# Altium schematic sheet IoTextra Analog_Diffirential Amplifier.SchDoc("IoTextra Analog_Diffirential Amplifier")
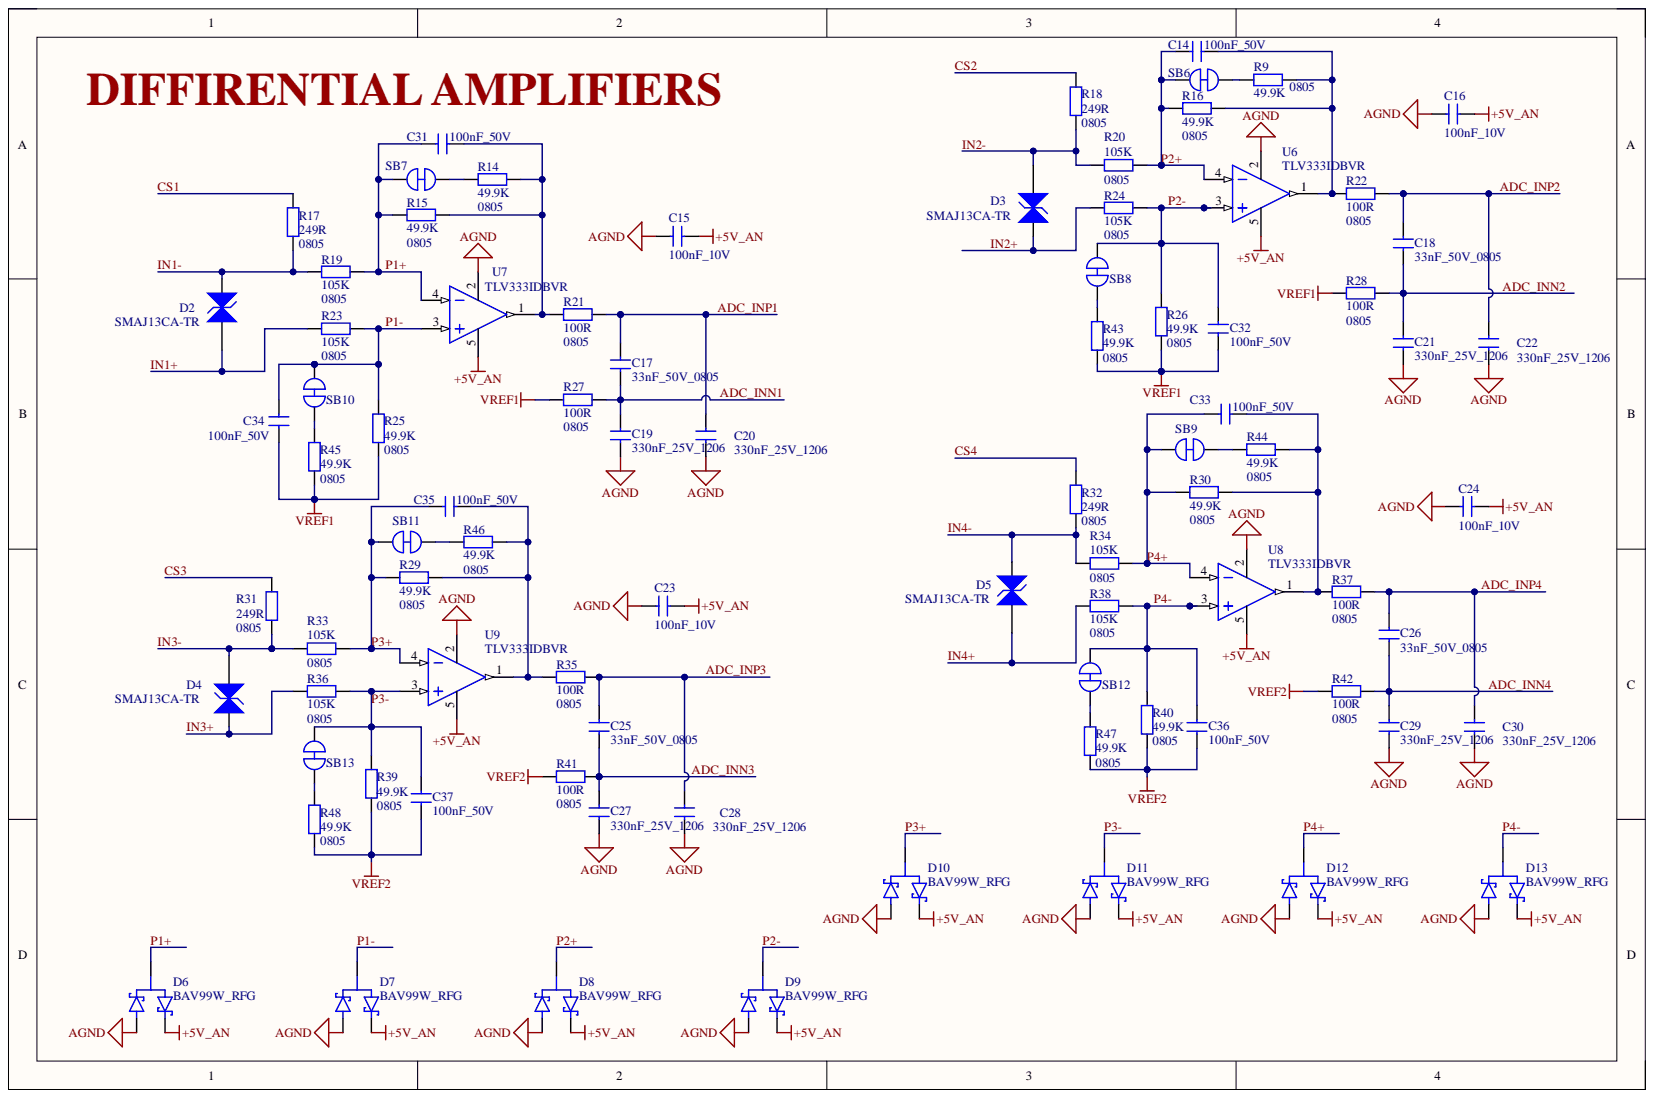
[diagram p0: IoTextra Analog_Diffirential Amplifier.SchDoc]

## Parts
C14  100nF_50V  [C1206]
C15  100nF_10V  [CN-SMD-0402]
C16  100nF_10V  [CN-SMD-0402]
C17  33nF_50V_0805  [C0805]
C18  33nF_50V_0805  [C0805]
C19  330nF_25V_1206  [C1206]
C20  330nF_25V_1206  [C1206]
C21  330nF_25V_1206  [C1206]
C22  330nF_25V_1206  [C1206]
C23  100nF_10V  [CN-SMD-0402]
C24  100nF_10V  [CN-SMD-0402]
C25  33nF_50V_0805  [C0805]
C26  33nF_50V_0805  [C0805]
C27  330nF_25V_1206  [C1206]
C28  330nF_25V_1206  [C1206]
C29  330nF_25V_1206  [C1206]
C30  330nF_25V_1206  [C1206]
C31  100nF_50V  [C1206]
C32  100nF_50V  [C1206]
C33  100nF_50V  [C1206]
C34  100nF_50V  [C1206]
C35  100nF_50V  [C1206]
C36  100nF_50V  [C1206]
C37  100nF_50V  [C1206]
D2  SMAJ13CA-TR  [DIODE_DO214AC_SMA]
D3  SMAJ13CA-TR  [DIODE_DO214AC_SMA]
D4  SMAJ13CA-TR  [DIODE_DO214AC_SMA]
D5  SMAJ13CA-TR  [DIODE_DO214AC_SMA]
D6  BAV99W_RFG  [DIO_BAV99W RF]
D7  BAV99W_RFG  [DIO_BAV99W RF]
D8  BAV99W_RFG  [DIO_BAV99W RF]
D9  BAV99W_RFG  [DIO_BAV99W RF]
D10  BAV99W_RFG  [DIO_BAV99W RF]
D11  BAV99W_RFG  [DIO_BAV99W RF]
D12  BAV99W_RFG  [DIO_BAV99W RF]
D13  BAV99W_RFG  [DIO_BAV99W RF]
R9  49.9K  [0805]
R14  49.9K  [0805]
R15  49.9K  [0805]
R16  49.9K  [0805]
R17  249R  [0805]
R18  249R  [0805]
R19  105K  [0805]
R20  105K  [0805]
R21  100R  [0805]
R22  100R  [0805]
R23  105K  [0805]
R24  105K  [0805]
R25  49.9K  [0805]
R26  49.9K  [0805]
R27  100R  [0805]
R28  100R  [0805]
R29  49.9K  [0805]
R30  49.9K  [0805]
R31  249R  [0805]
R32  249R  [0805]
R33  105K  [0805]
R34  105K  [0805]
R35  100R  [0805]
R36  105K  [0805]
R37  100R  [0805]
R38  105K  [0805]
R39  49.9K  [0805]
R40  49.9K  [0805]
R41  100R  [0805]
R42  100R  [0805]
R43  49.9K  [0805]
R44  49.9K  [0805]
R45  49.9K  [0805]
R46  49.9K  [0805]
R47  49.9K  [0805]
R48  49.9K  [0805]
SB6  SOLDER_JUMPER_NC  [SOLDER_JUMPER_NC]  (value not drawn)
SB7  SOLDER_JUMPER_NC  [SOLDER_JUMPER_NC]  (value not drawn)
SB8  SOLDER_JUMPER_NC  [SOLDER_JUMPER_NC]  (value not drawn)
SB9  SOLDER_JUMPER_NC  [SOLDER_JUMPER_NC]  (value not drawn)
SB10  SOLDER_JUMPER_NC  [SOLDER_JUMPER_NC]  (value not drawn)
SB11  SOLDER_JUMPER_NC  [SOLDER_JUMPER_NC]  (value not drawn)
SB12  SOLDER_JUMPER_NC  [SOLDER_JUMPER_NC]  (value not drawn)
SB13  SOLDER_JUMPER_NC  [SOLDER_JUMPER_NC]  (value not drawn)
U6  TLV333IDBVR  [SOT23-5]
U7  TLV333IDBVR  [SOT23-5]
U8  TLV333IDBVR  [SOT23-5]
U9  TLV333IDBVR  [SOT23-5]

## Nets
+5V_AN: C15.2 C16.2 C23.2 C24.2 D6.2 D7.2 D8.2 D9.2 D10.2 D11.2 D12.2 D13.2 U6.5 U7.5 U8.5 U9.5
AGND: C15.1 C16.1 C19.1 C20.1 C21.1 C22.1 C23.1 C24.1 C27.1 C28.1 C29.1 C30.1 D6.1 D7.1 D8.1 D9.1 D10.1 D11.1 D12.1 D13.1 U6.2 U7.2 U8.2 U9.2
VREF1: C32.1 C34.1 R25.1 R26.1 R27.1 R28.1 R43.1 R45.1
VREF2: C36.1 C37.1 R39.1 R40.1 R41.1 R42.1 R47.1 R48.1
ADC_INN1: C17.1 C19.2 R27.2
ADC_INN2: C18.1 C21.2 R28.2
ADC_INN3: C25.1 C27.2 R41.2
ADC_INN4: C26.1 C29.2 R42.2
ADC_INP1: C17.2 C20.2 R21.2
ADC_INP2: C18.2 C22.2 R22.2
ADC_INP3: C25.2 C28.2 R35.2
ADC_INP4: C26.2 C30.2 R37.2
CS1: R17.1
CS2: R18.1
CS3: R31.1
CS4: R32.1
IN1+: D2.1 R23.1
IN1-: D2.2 R17.2 R19.1
IN2+: D3.2 R24.1
IN2-: D3.1 R18.2 R20.1
IN3+: D4.2 R36.1
IN3-: D4.1 R31.2 R33.1
IN4+: D5.1 R38.1
IN4-: D5.2 R32.2 R34.1
P1+: C31.2 D6.3 R15.1 R19.2 SB7.1 U7.4
P1-: C34.2 D7.3 R23.2 R25.2 SB10.2 U7.3
P2+: C14.2 D8.3 R16.1 R20.2 SB6.1 U6.4
P2-: C32.2 D9.3 R24.2 R26.2 SB8.2 U6.3
P3+: C35.2 D10.3 R29.1 R33.2 SB11.1 U9.4
P3-: C37.2 D11.3 R36.2 R39.2 SB13.2 U9.3
P4+: C33.2 D12.3 R30.1 R34.2 SB9.1 U8.4
P4-: C36.2 D13.3 R38.2 R40.2 SB12.2 U8.3
NetC14_1: C14.1 R9.2 R16.2 R22.1 U6.1
NetC31_1: C31.1 R14.2 R15.2 R21.1 U7.1
NetC33_1: C33.1 R30.2 R37.1 R44.2 U8.1
NetC35_1: C35.1 R29.2 R35.1 R46.2 U9.1
NetR9_1: R9.1 SB6.2
NetR14_1: R14.1 SB7.2
NetR43_2: R43.2 SB8.1
NetR44_1: R44.1 SB9.2
NetR45_2: R45.2 SB10.1
NetR46_1: R46.1 SB11.2
NetR47_2: R47.2 SB12.1
NetR48_2: R48.2 SB13.1

## Library data of the parts
C14 C31-C37 = GRM31C5C1H104JA01L: 100nF 50V C0G ±5% 1206 Multilayer Ceramic Capacitors MLCC - SMD/SMT ROHS; Component Kind: Standard; LCSC #: C97946; Value: GRM31C5C1H104JA01L; Library Name: Bokra.SCHLIB
C15 C16 C23 C24 = CL05B104KP5NNNC: 10V 100nF X7R ±10% 0402 Multilayer Ceramic Capacitors MLCC - SMD/SMT ROHS; Component Kind: Standard; LCSC #: C133086; Library Name: Bokra.SCHLIB
C17 C18 C25 C26 = C2012C0G1H333JT000N: 33nF 50V C0G ±5% 0805 Multilayer Ceramic Capacitors MLCC - SMD/SMT ROHS; Component Kind: Standard; LCSC #: C76628; Library Name: Bokra.SCHLIB
C19-C22 C27-C30 = GRM31C5C1E334JE01L: 25V 330nF C0G ±5% 1206 Multilayer Ceramic Capacitors MLCC - SMD/SMT ROHS; Component Kind: Standard; LCSC #: C3837965; Library Name: Bokra.SCHLIB
D2-D5 = SMAJ13CA-TR: -55℃~+150℃ 13V 14.4V 18.6A 200nA 27.2V 400W Bidirectional IEC 61000-4-2 TVS SMA(DO-214AC) ESD and Surge Protection (TVS/ESD) ROHS; Component Kind: Standard; LCSC #: C2935145; MPN: SMAJ13CA-TR; Library Name: Bokra.SCHLIB
D6-D13 = BAV99W_RFG: SOT-323, 85V, 0.15A, Switching Diode; MF: Taiwan Semiconductor; MP: BAV99W RF; Value: BAV99W; Component Kind: Standard; LCSC #: C26216; Package: SOT-323-3 Taiwan Semiconductor; SnapEDA_Link: https://www.snapeda.com/parts/BAV99W%20RF/Taiwan+Semiconductor/view-part/?ref=snap; Library Name: Bokra.SCHLIB
R9 R14-R16 R25 R26 R29 R30 R39 R40 R43-R48 = PTFR0805B49K9N9: -55℃~+155℃ 125mW 150V 49.9kΩ Thin Film Resistor ±0.1% ±10ppm/℃ 0805 Chip Resistor - Surface Mount ROHS; Solder: SMT; Component Kind: Standard; LCSC #: C5382601; MPN: PTFR0805B49K9N9; Value: 49.9K; Library Name: Bokra.SCHLIB
R17 R18 R31 R32 = PTFR0805B249RN9: -55℃~+155℃ 130mW 150V 249Ω Thin Film Resistor ±0.1% ±10ppm/℃ 0805 Chip Resistor - Surface Mount ROHS; Solder: SMT; Component Kind: Standard; LCSC #: C5382583; MPN: PTFR0805B249RN9; Value: 249R; Library Name: Bokra.SCHLIB
R19 R20 R23 R24 R33 R34 R36 R38 = PTFR0805B105KN9: -55℃~+125℃ 105kΩ 130mW 150V Thin Film Resistor ±0.1% ±10ppm/℃ 0805 Chip Resistor - Surface Mount ROHS; Solder: SMT; Component Kind: Standard; LCSC #: C2692778; MPN: PTFR0805B105KN9; Value: 105K; Library Name: Bokra.SCHLIB
R21 R22 R27 R28 R35 R37 R41 R42 = PTFR0805B100RN9: -55℃~+125℃ 100Ω 130mW 150V Thin Film Resistor ±0.1% ±10ppm/℃ 0805 Chip Resistor - Surface Mount ROHS; Solder: SMT; Component Kind: Standard; LCSC #: C328435; MPN: PTFR0805B100RN9; Value: 100R; Library Name: Bokra.SCHLIB
SB6-SB13 = SOLDER_JUMPER_NC: Solder Jumper; Component Kind: Net Tie; Library Name: Bokra.SCHLIB
U6-U9 = TLV333IDBVR: -2.75V~-900mV -40℃~+125℃ 0.16V/us 1.0 1.8V~5.5V 115dB 140pA 17uA 20nV/℃ 2uV 30mA 350kHz 5.5V 55nV/√Hz@1kHz 70pA Rail-to-Rail Input, Rail-to-Rail Output SOT-23-5 Precision Op Amps ROHS; Component Kind: Standard; LCSC #: C473369; Value: TLV333IDBVR; Library Name: Bokra.SCHLIB
